# Altium schematic sheet p11_LCD & MIPI.SchDoc
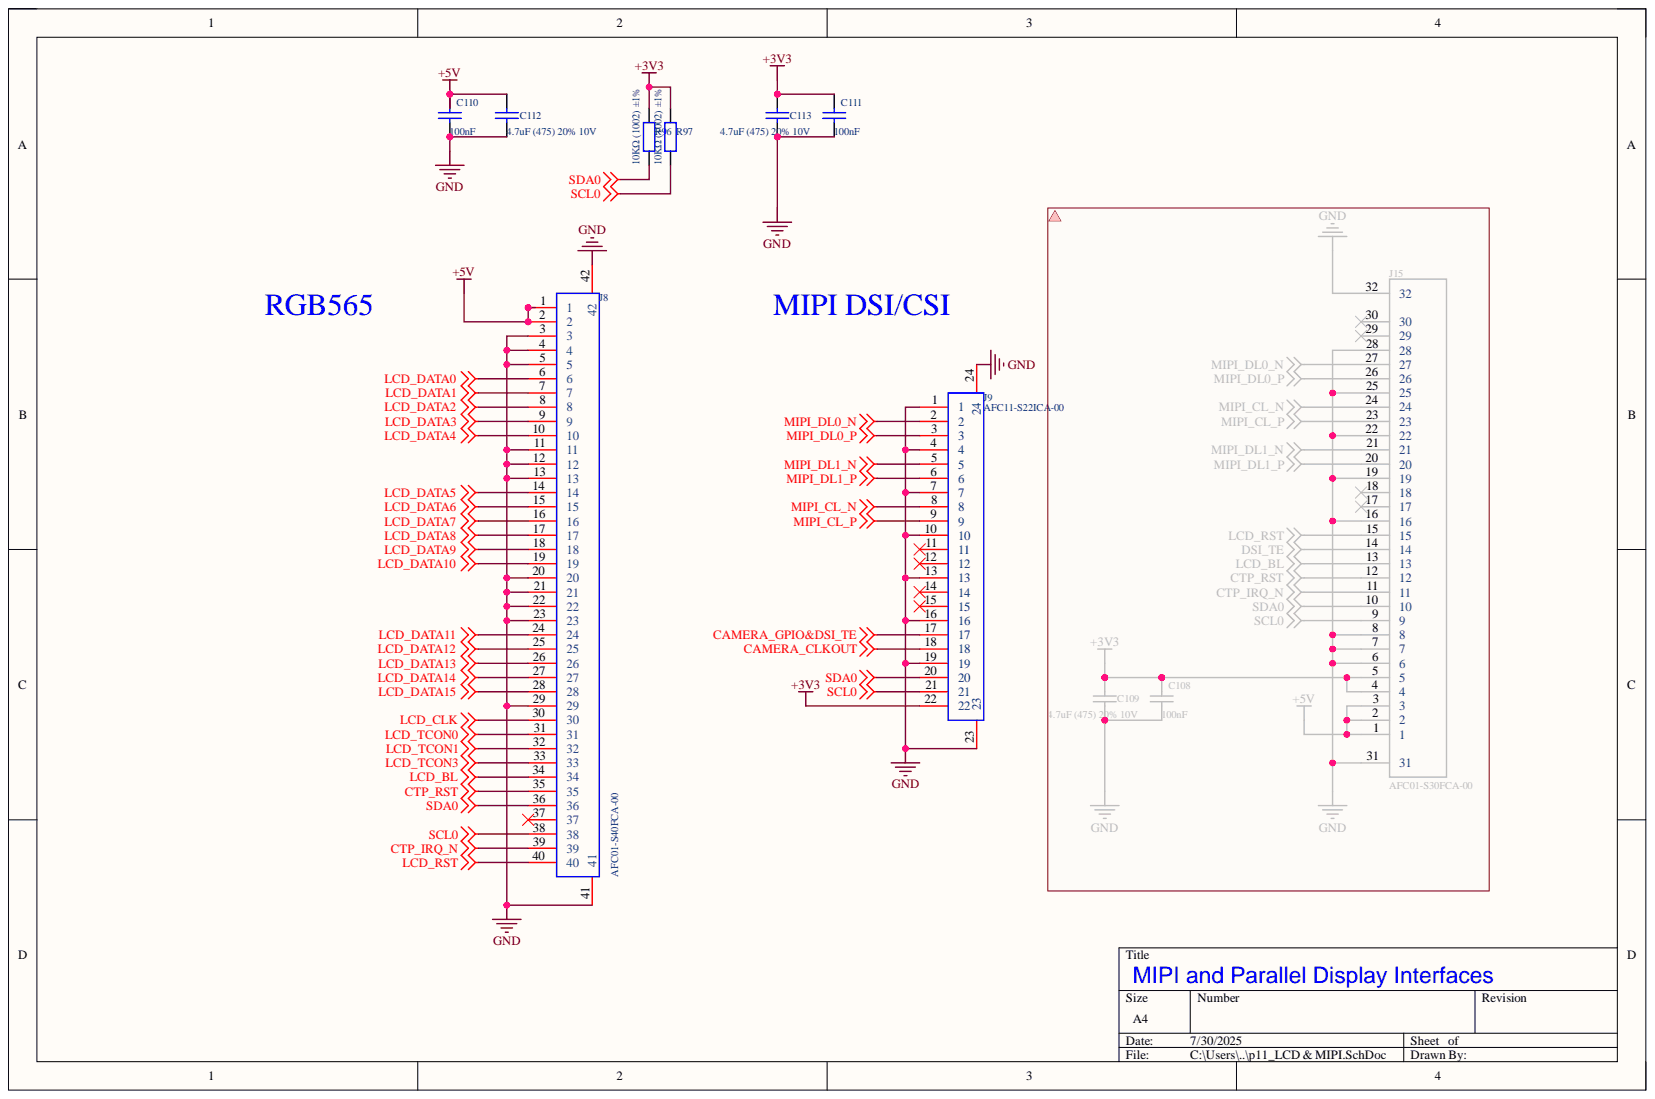
[diagram p0: p11_LCD & MIPI.SchDoc]

## Parts
C110  Capacitance: 100nF  [C0402]  (hidden comment: 100 nf (0.1uF) 16V)
C111  Capacitance: 100nF  [C0402]  (hidden comment: 100 nf (0.1uF) 16V)
C112  4.7uF (475) 20% 10V  [C0402]
C113  4.7uF (475) 20% 10V  [C0402]
J8  AFC24-S40FIA-00  [FPC-SMD_P0.50-40P_FGS-XJ-H2.0]  (value not drawn)
J9  AFC11-S22ICA-00  [FPC-SMD_22P-P1.00_AFC11-S22ICC-00]
R96  10KΩ (1002) ±1%  [R0402]
R97  10KΩ (1002) ±1%  [R0402]

## Nets
+3V3: C111.2 C113.2 J9.22 R96.2 R97.2
+5V: C110.2 C112.2 J8.1 J8.2
CAMERA_CLKOUT: J9.18
CAMERA_GPIO&DSI_TE: J9.17
CTP_IRQ_N: J8.39
CTP_RST: J8.35
GND: C110.1 C111.1 C112.1 C113.1 J8.3 J8.4 J8.5 J8.11 J8.12 J8.13 J8.20 J8.21 J8.22 J8.23 J8.29 J8.41 J8.42 J9.1 J9.4 J9.7 J9.10 J9.13 J9.16 J9.19 J9.23 J9.24
LCD_BL: J8.34
LCD_CLK: J8.30
LCD_DATA0: J8.6
LCD_DATA1: J8.7
LCD_DATA2: J8.8
LCD_DATA3: J8.9
LCD_DATA4: J8.10
LCD_DATA5: J8.14
LCD_DATA6: J8.15
LCD_DATA7: J8.16
LCD_DATA8: J8.17
LCD_DATA9: J8.18
LCD_DATA10: J8.19
LCD_DATA11: J8.24
LCD_DATA12: J8.25
LCD_DATA13: J8.26
LCD_DATA14: J8.27
LCD_DATA15: J8.28
LCD_RST: J8.40
LCD_TCON0: J8.31
LCD_TCON1: J8.32
LCD_TCON3: J8.33
MIPI_CL_N: J9.8
MIPI_CL_P: J9.9
MIPI_DL0_N: J9.2
MIPI_DL0_P: J9.3
MIPI_DL1_N: J9.5
MIPI_DL1_P: J9.6
SCL0: J8.38 J9.21 R97.1
SDA0: J8.36 J9.20 R96.1

Not connected: J8 37; J9 11 12 14 15

## Library data of the parts
C110 C111 = CAP-000030-01: Ceramic Chip Capacitor 0402 100 nf (0.1uF) 16V; Legacy Part Number: 151-10004022; Manufacturer: Yageo; Manufacturer Part Number 1: CC0402KRX7R8BB104; Dialectric: X7R; Notes: ANY EQUIVALENT; Tolerance: 10%; Component Kind: Standard; Library Name: ra8p1_v0.1.SCHLIB; Manufacturer 1: Yageo; Manufacturer 2: Kemet; Manufacturer 3: AVX; Manufacturer Part Number 2: C0402C104K4RACTU; Manufacturer Part Number 3: 0402YC104KAT2A; Mfg: Yageo; Mfg P/N: CC0402KRX7R8BB104; Mfg Part Number: CC0402KRX7R8BB104; Package: 0402; PN: CC0402KRX7R8BB104; Supplier 1: Arrow Electronics; Supplier 2: Digi-Key; Supplier 3: Newark; Supplier 4: Mouser; Supplier Part Number 1: CC0402KRX7R8BB104; Supplier Part Number 2: 311-2077-1-ND; Supplier Part Number 3: 40AJ6370; Supplier Part Number 4: 603-CC402KRX7R8BB104; Voltage: 16V
C112 C113 = 0402 4.7uF (475) 20% 10V: 贴片电容; Component Kind: Standard; ComponentLink1Description: 供应商链接; ComponentLink1URL: http://www.szlcsc.com/product/details_24469.html; Library Name: Cap.SchLib; Package: 0402; Supplier: LC; SuppliersPartNumber: C23733
J8 = AFC01-S40FCA-00: FPC,0.5mm,0.3mm,40p; Manufacturer: JS(钜硕电子); Add into BOM: yes; Locking Feature: 翻盖式; Component Kind: Standard; Contact Type: 下接; Convert to PCB: yes; Datasheet: https://atta.szlcsc.com/upload/public/pdf/source/20190816/C413942_2A72D1AC756EAE17EEDF756A339D4500.pdf; Device: AFC01-S40FCA-00; FFC, FCB Thickness: 0.3mm; Height Above Board: 2mm; JLCPCB Part Class: Extended Part; LCSC Part Name: 间距:0.5mm P数:40P 翻盖式 下接; Library Name: ra8p1_v0.2.SCHLIB; Manufacturer Part: AFC01-S40FCA-00; Mounting Type: 卧贴; Number of Contacts: 40P; PCB3D: FPC-SMD_P0.50-40P_FGS-XJ-H2.0; Pitch: 0.5mm; Supplier: LCSC; Supplier Footprint: SMD,P=0.5mm,卧贴; Supplier Part: C262674; Symbol: AFC01-S40FCA-00
J9 = AFC11-S22ICA-00: FPC，立贴，P=0.5mm; Manufacturer: JS(钜硕电子); Add into BOM: yes; Locking Feature: 抽屉式; Number of Contacts: 22P; Pitch: 0.5mm; Component Kind: Standard; Contact Type: 单侧触点/垂直; Convert to PCB: yes; Datasheet: https://atta.szlcsc.com/upload/public/pdf/source/20191211/C466537_F6F2472ADACFFA77E875AFE9DAB8E0AD.pdf; Device: AFC11-S22ICA-00; Height Above Board: 5.65mm; JLCPCB Part Class: Extended Part; LCSC Part Name: 间距:0.5mm P数:22P 抽屉式 单侧触点/垂直; Library Name: ra8p1_v0.1.SCHLIB; Manufacturer Part: AFC11-S22ICA-00; Mounting Type: 立贴; PCB3D: FPC-SMD_22P-P1.00_AFC11-S22ICC-00; Supplier: LCSC; Supplier Footprint: SMD,P=0.5mm; Supplier Part: C5248019; Symbol: AFC11-S22ICA-00
R96 R97 = 0402 10KΩ (1002) 1%: 贴片电阻，10K; Component Kind: Standard; ComponentLink1Description: 供应商链接; ComponentLink1URL: http://www.szlcsc.com/product/details_26487.html; Library Name: RES.SchLib; Package: 0402; Supplier: LC; SuppliersPartNumber: C25744
